# Altium schematic sheet power_supply.SchDoc
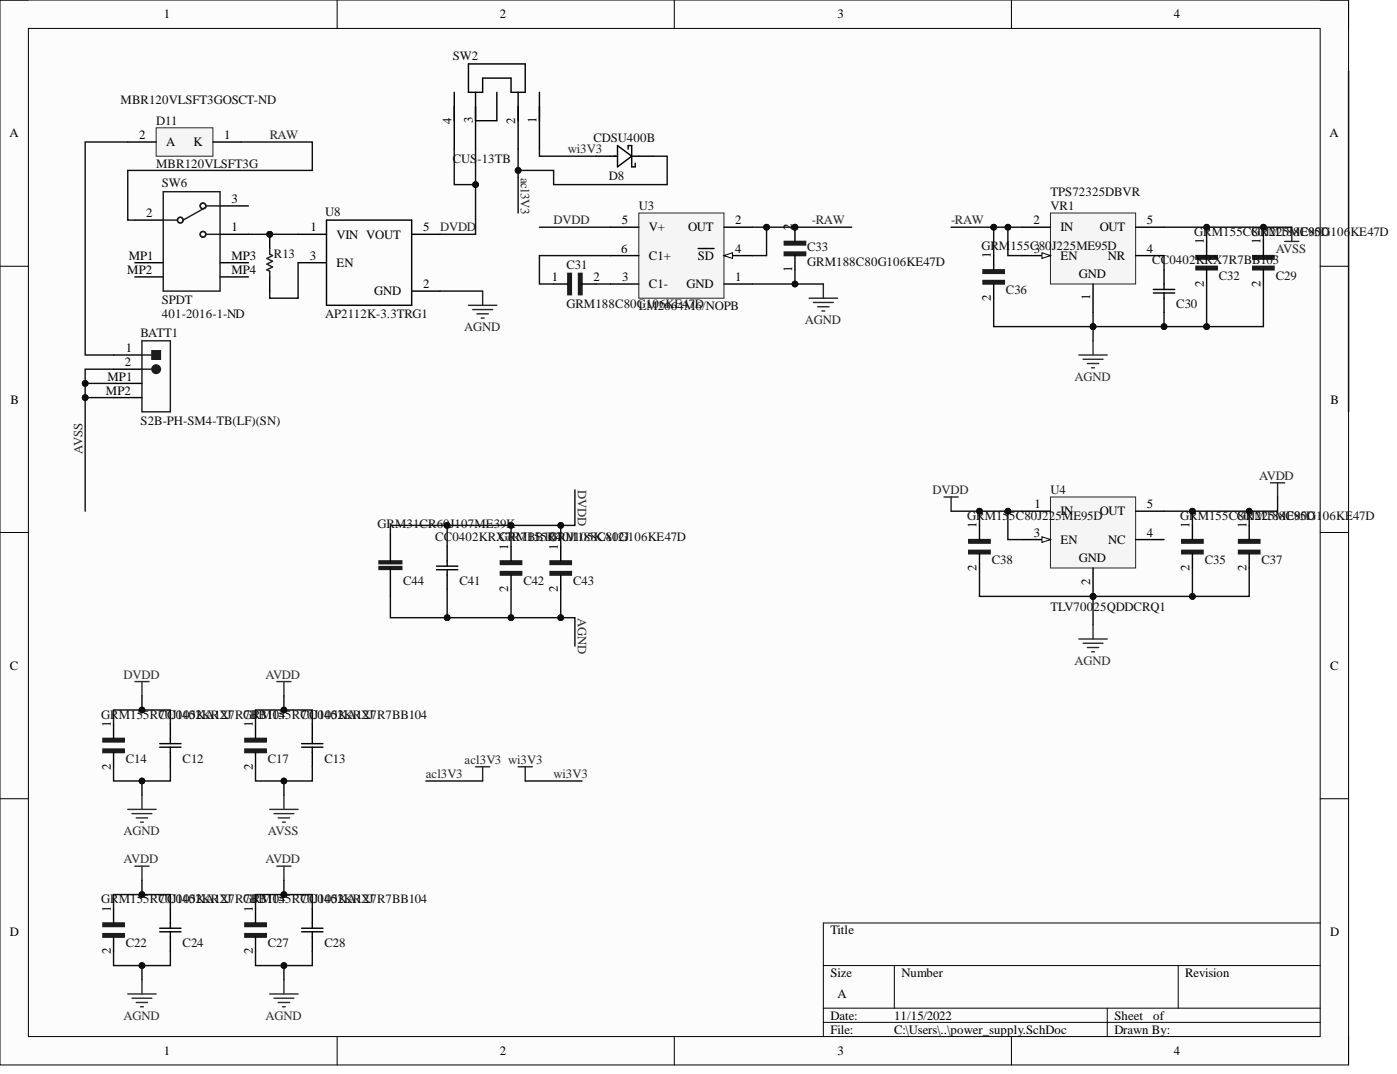
[diagram p0: power_supply.SchDoc]

## Parts
BATT1  S2B-PH-SM4-TB(LF)(SN)  [JST- S2B-PH-SM4-TB(LF)(SN)-2_V]
C12  CC0402KRX7R7BB104  [YAG_CC0402]
C13  CC0402KRX7R7BB104  [YAG_CC0402]
C14  GRM155R70J105KA12J  [*CAPC1005X55N]
C17  GRM155R70J105KA12J  [*CAPC1005X55N]
C22  GRM155R70J105KA12J  [*CAPC1005X55N]
C24  CC0402KRX7R7BB104  [YAG_CC0402]
C27  GRM155R70J105KA12J  [*CAPC1005X55N]
C28  CC0402KRX7R7BB104  [YAG_CC0402]
C29  GRM188C80G106KE47D  [*CAPC1608X90N]
C30  CC0402KRX7R7BB103  [CC0402N]
C31  GRM188C80G106KE47D  [*CAPC1608X90N]
C32  GRM155C80J225ME95D  [*CAPC1005X55N]
C33  GRM188C80G106KE47D  [*CAPC1608X90N]
C35  GRM155C80J225ME95D  [*CAPC1005X55N]
C36  GRM155C80J225ME95D  [*CAPC1005X55N]
C37  GRM188C80G106KE47D  [*CAPC1608X90N]
C38  GRM155C80J225ME95D  [*CAPC1005X55N]
C41  CC0402KRX7R7BB104  [YAG_CC0402]
C42  GRM155R70J105KA12J  [*CAPC1005X55N]
C43  GRM188C80G106KE47D  [*CAPC1608X90N]
C44  GRM31CR60J107ME39K  [*CAPC3216X190]
D8  CDSU400B  [CDBU]
D11  MBR120VLSFT3G  Supplier Part Number 1: MBR120VLSFT3GOSCT-ND  [ONSC-SOD-123FL-2-498-01_V]
R13  10K  [RESC1609X55X30NL15T15]  (value not drawn)
SW2  CUS-13TB  [CUS-13TB]
SW6  SPDT  [CKCP-PCM12SMTR_V]
U3  LM2664M6/NOPB  [DBV0006A_N]
U4  TLV70025QDDCRQ1  [DDC0005A_N]
U8  AP2112K-3.3TRG1  [*SOT95P285X140-5N]
VR1  TPS72325DBVR  [DBV0005A_N]

## Nets
AVDD: C13.1 C17.1 C22.1 C24.1 C27.1 C28.1 C35.1 C37.1 U4.5(OUT)
-RAW: C33.2 C36.1 U3.2(OUT) U3.4(SD) VR1.2(IN) VR1.3(EN)
acl3V3: D8.1 SW2.2
AGND: C12.2 C14.2 C22.2 C24.2 C27.2 C28.2 C29.2 C30.1 C32.2 C33.1 C35.2 C36.2 C37.2 C38.2 C41.2 C42.2 C43.2 C44.2 U3.1(GND) U4.2 U8.2(GND) VR1.1
AVSS: BATT1.2 BATT1.MP1 BATT1.MP2 C13.2 C17.2 C29.1 C32.1 VR1.5(OUT)
DVDD: C12.1 C14.1 C38.1 C41.1 C42.1 C43.1 C44.1 SW2.3 SW2.4 U3.5(V+) U4.1(IN) U4.3(EN) U8.5(VOUT)
RAW: D11.1 SW6.2
wi3V3: D8.2 SW2.1
NetBATT1_1: BATT1.1 D11.2
NetC30_2: C30.2 VR1.4(NR)
NetC31_1: C31.1 U3.6(C1+)
NetC31_2: C31.2 U3.3(C1-)
NetR13_1: R13.1 U8.3(EN)
NetR13_2: R13.2 SW6.1 U8.1(VIN)

Not connected: SW6 3 MP1 MP2 MP3 MP4; U4 4(NC)

## Library data of the parts
BATT1 = S2B-PH-SM4-TB(LF)(SN): Manufacturer 1: JST; Component Kind: Standard; Manufacturer Part Number 1: S2B-PH-SM4-TB(LF)(SN); Pricing 1: 1=0.58000, 10=0.54700, 100=0.41940, 500=0.36470 (USD); RoHS: No; Stock 1: 99373; Supplier 1: Digi-Key; Supplier Part Number 1: 455-1749-1-ND; Library Name: EE_smallOB_BW_v1.0.SchLib
C12 C13 C24 C28 C41 = CC0402KRX7R7BB104: CAP CER 0.1UF 16V X7R 0402; Manufacturer 1: Yageo; Capacitance: 100nF; Tolerance: 10%; Case Code (Imperial): 0402; Case Code (Metric): 1005; Case/Package: 0402; Component Kind: Standard; ComponentLink1Description: https://datasheet.ciiva.com/11788/0900766b80ce67ed-11788364.pdf?src-supplier=RSComponents; ComponentLink1URL: https://datasheet.ciiva.com/11788/0900766b80ce67ed-11788364.pdf?src-supplier=RSComponents; ComponentLink2Description: https://datasheet.ciiva.com/16625/upy-gphc-x7r-6-3v-to-50v-8-16625511.pdf?src-supplier=Avnet+Europe; ComponentLink2URL: https://datasheet.ciiva.com/16625/upy-gphc-x7r-6-3v-to-50v-8-16625511.pdf?src-supplier=Avnet+Europe; ComponentLink3Description: https://datasheet.ciiva.com/161/mlccorderingcode-161544.pdf?src-supplier=Digi-Key; ComponentLink3URL: https://datasheet.ciiva.com/161/mlccorderingcode-161544.pdf?src-supplier=Digi-Key; ComponentLink4Description: https://datasheet.ciiva.com/161/mlccorderingcode-161545.pdf?src-supplier=Digi-Key; ComponentLink4URL: https://datasheet.ciiva.com/161/mlccorderingcode-161545.pdf?src-supplier=Digi-Key; ComponentLink5Description: https://datasheet.ciiva.com/8033/1734573-8033661.pdf?src-supplier=Element14; ComponentLink5URL: https://datasheet.ciiva.com/8033/1734573-8033661.pdf?src-supplier=Element14; ComponentLink6Description: https://datasheet.ciiva.com/7978/1489800-7978111.pdf?src-supplier=Element14; ComponentLink6URL: https://datasheet.ciiva.com/7978/1489800-7978111.pdf?src-supplier=Element14; ComponentLink7Description: https://datasheet.ciiva.com/8033/1734573-8033663.pdf?src-supplier=Element14; ComponentLink7URL: https://datasheet.ciiva.com/8033/1734573-8033663.pdf?src-supplier=Element14; ComponentLink8Description: https://datasheet.ciiva.com/11587/upy-gphc-x7r-6-3v-to-50v-8-11587305.pdf?src-supplier=Avnet; ComponentLink8URL: https://datasheet.ciiva.com/11587/upy-gphc-x7r-6-3v-to-50v-8-11587305.pdf?src-supplier=Avnet; ComponentLink9Description: https://datasheet.ciiva.com/161/mlccorderingcode-161546.pdf?src-supplier=Digi-Key; ComponentLink9URL: https://datasheet.ciiva.com/161/mlccorderingcode-161546.pdf?src-supplier=Digi-Key; ComponentLink10Description: https://datasheet.ciiva.com/13395/upy-gphc-x7r-6-3v-to-50v-8-13395676.pdf?src-supplier=Mouser; ComponentLink10URL: https://datasheet.ciiva.com/13395/upy-gphc-x7r-6-3v-to-50v-8-13395676.pdf?src-supplier=Mouser; ComponentLink11Description: https://datasheet.ciiva.com/28450/1489800-28450335.pdf?src-supplier=Element14; ComponentLink11URL: https://datasheet.ciiva.com/28450/1489800-28450335.pdf?src-supplier=Element14; Composition: Ceramic; Depth: 0.5mm; Dielectric: X7R; Halogen Free: Halogen Free; Height: 0.5mm; Lead Free: Lead Free; Length: 1mm; Manufacturer Part Number 1: CC0402KRX7R7BB104; Max Operating Temperature: 125°C; Min Operating Temperature: -55°C; Mount: Surface Mount; Number of Pins: 2; Package Quantity: 1; Packaging: Tape and Reel; Pricing 1: 1=0.10000, 10=0.03200, 100=0.01420, 500=0.01014, 1000=0.00797, 2500=0.00724, 5000=0.00667 (USD); Radiation Hardening: No; REACH SVHC: No SVHC; RoHS: Yes; Stock 1: 30032352; Supplier 1: Digi-Key; Supplier Part Number 1: 311-1338-1-ND; Termination: SMD/SMT; Thickness: 0.022inch; Voltage: 16V; Voltage Rating: 16V; Voltage Rating (DC): 16V; Width: 0.02inch; Library Name: EE_smallOB_BW_v1.0.SchLib
C14 C17 C22 C27 C42 = GRM155R70J105KA12J: CAP CER 1UF 6.3V X7R 0402; Manufacturer 1: Murata; Tolerance: 10%; Capacitance: 1uF; Case Code (Imperial): 0402; Case Code (Metric): 1005; Case/Package: 0402; Component Kind: Standard; ComponentLink1Description: http://www.mouser.com/ds/2/281/c02e-2905.pdf?src-supplier=Mouser; ComponentLink1URL: http://www.mouser.com/ds/2/281/c02e-2905.pdf?src-supplier=Mouser; ComponentLink2Description: http://search.murata.co.jp/Ceramy/image/img/A01X/G101/ENG/GRM155R70J105KA12-01.pdf?src-supplier=Digi-Key; ComponentLink2URL: http://search.murata.co.jp/Ceramy/image/img/A01X/G101/ENG/GRM155R70J105KA12-01.pdf?src-supplier=Digi-Key; Dielectric: X7R; Length: 0.039inch; Manufacturer Part Number 1: GRM155R70J105KA12J; Max Operating Temperature: 125°C; Min Operating Temperature: -55°C; Mount: Surface Mount; Package Quantity: 50000; Packaging: Tape and Reel; Pricing 1: 1=0.11000, 10=0.07900, 100=0.03700, 500=0.02596, 1000=0.02149, 2500=0.02000, 5000=0.01830 (USD); RoHS: Yes; Stock 1: 3268614; Supplier 1: Digi-Key; Supplier Part Number 1: 490-13339-1-ND; Termination: SMD/SMT; Thickness: 0.022inch; Voltage Rating: 6.3V; Voltage Rating (DC): 6.3V; Weight: 0.000212oz; Width: 0.02inch; Library Name: EE_smallOB_BW_v1.0.SchLib
C29 C31 C33 C37 C43 = GRM188C80G106KE47D: CAP CER 10UF 4V X6S 0603; Manufacturer 1: Murata; Tolerance: 10%; Capacitance: 10uF; Case Code (Imperial): 0603; Case Code (Metric): 1608; Case/Package: 0603; Component Kind: Standard; ComponentLink1Description: http://www.mouser.com/ds/2/281/c02e-2905.pdf?src-supplier=Mouser; ComponentLink1URL: http://www.mouser.com/ds/2/281/c02e-2905.pdf?src-supplier=Mouser; ComponentLink2Description: http://search.murata.co.jp/Ceramy/image/img/A01X/G101/ENG/GRM188C80G106KE47-01.pdf?src-supplier=Digi-Key; ComponentLink2URL: http://search.murata.co.jp/Ceramy/image/img/A01X/G101/ENG/GRM188C80G106KE47-01.pdf?src-supplier=Digi-Key; Dielectric: X6S; Height: 0.8mm; Length: 0.063inch; Manufacturer Part Number 1: GRM188C80G106KE47D; Max Operating Temperature: 105°C; Min Operating Temperature: -55°C; Mount: Surface Mount; Package Quantity: 4000; Packaging: Tape and Reel; Pricing 1: 1=0.17000, 10=0.11800, 100=0.05990, 500=0.04098, 1000=0.03625 (USD); RoHS: Yes; Stock 1: 203819; Supplier 1: Digi-Key; Supplier Part Number 1: 490-10469-1-ND; Termination: SMD/SMT; Thickness: 0.035inch; Voltage Rating: 4V; Voltage Rating (DC): 4V; Weight: 0.000222oz; Width: 0.031inch; Library Name: EE_smallOB_BW_v1.0.SchLib
C30 = CC0402KRX7R7BB103: CAP CER 10000PF 16V X7R 0402; Manufacturer 1: Yageo; Capacitance: 10nF; Dissipation Factor: 3.5%; Insulation Resistance: 10GR; Tolerance: 10%; Case Code (Imperial): 0402; Case Code (Metric): 1005; Case/Package: 0402; Component Kind: Standard; ComponentLink1Description: https://datasheet.ciiva.com/143/upy-gphc-x7r-6-3v-to-50v-8-143952.pdf?src-supplier=Digi-Key; ComponentLink1URL: https://datasheet.ciiva.com/143/upy-gphc-x7r-6-3v-to-50v-8-143952.pdf?src-supplier=Digi-Key; ComponentLink2Description: https://datasheet.ciiva.com/143/mlccorderingcode-143950.pdf?src-supplier=Digi-Key; ComponentLink2URL: https://datasheet.ciiva.com/143/mlccorderingcode-143950.pdf?src-supplier=Digi-Key; ComponentLink3Description: https://datasheet.ciiva.com/143/mlccorderingcode-143951.pdf?src-supplier=Digi-Key; ComponentLink3URL: https://datasheet.ciiva.com/143/mlccorderingcode-143951.pdf?src-supplier=Digi-Key; ComponentLink4Description: https://datasheet.ciiva.com/16625/upy-gphc-x7r-6-3v-to-50v-8-16625510.pdf?src-supplier=Avnet+Europe; ComponentLink4URL: https://datasheet.ciiva.com/16625/upy-gphc-x7r-6-3v-to-50v-8-16625510.pdf?src-supplier=Avnet+Europe; ComponentLink5Description: https://datasheet.ciiva.com/8033/70765-8033655.pdf?src-supplier=Element14; ComponentLink5URL: https://datasheet.ciiva.com/8033/70765-8033655.pdf?src-supplier=Element14; ComponentLink6Description: https://datasheet.ciiva.com/8033/1489800-8033658.pdf?src-supplier=Element14; ComponentLink6URL: https://datasheet.ciiva.com/8033/1489800-8033658.pdf?src-supplier=Element14; ComponentLink7Description: https://datasheet.ciiva.com/28450/1489800-28450330.pdf?src-supplier=Element14; ComponentLink7URL: https://datasheet.ciiva.com/28450/1489800-28450330.pdf?src-supplier=Element14; ComponentLink8Description: https://datasheet.ciiva.com/8033/1489800-8033659.pdf?src-supplier=Element14; ComponentLink8URL: https://datasheet.ciiva.com/8033/1489800-8033659.pdf?src-supplier=Element14; ComponentLink9Description: https://datasheet.ciiva.com/7978/1489800-7978109.pdf?src-supplier=Element14; ComponentLink9URL: https://datasheet.ciiva.com/7978/1489800-7978109.pdf?src-supplier=Element14; ComponentLink10Description: https://datasheet.ciiva.com/5425/upy-gp-np0-16v-to-50v-8-3714-5425438.pdf?src-supplier=Mouser; ComponentLink10URL: https://datasheet.ciiva.com/5425/upy-gp-np0-16v-to-50v-8-3714-5425438.pdf?src-supplier=Mouser; Depth: 0.5mm; Dielectric: X7R; Halogen Free: Halogen Free; Height: 0.5mm; Lead Free: Lead Free; Length: 1mm; Manufacturer Part Number 1: CC0402KRX7R7BB103; Max Operating Temperature: 125°C; Min Operating Temperature: -55°C; Mount: Surface Mount; Number of Pins: 2; Package Quantity: 1; Packaging: Tape and Reel; Pricing 1: 1=0.10000, 10=0.01900, 100=0.00850, 500=0.00610, 1000=0.00479, 2500=0.00436, 5000=0.00401 (USD); Radiation Hardening: No; REACH SVHC: No SVHC; RoHS: Yes; Stock 1: 1055821; Supplier 1: Digi-Key; Supplier Part Number 1: 311-1042-1-ND; Termination: SMD/SMT; Thickness: 0.022inch; Voltage: 16V; Voltage Rating: 16V; Voltage Rating (DC): 16V; Width: 0.02inch; Library Name: EE_smallOB_BW_v1.0.SchLib
C32 C35 C36 C38 = GRM155C80J225ME95D: 0402 6.3 V 2.2 uF ±20% Tolerance X6S Monolithic Ceramic Chip Capacitor; Manufacturer 1: Murata; Capacitance: 2.2uF; Voltage Rating: 6.3V; Case Code (Imperial): 0402; Case Code (Metric): 1005; Case/Package: 0402; Component Kind: Standard; ComponentLink1Description: http://www.mouser.com/ds/2/281/c02e-2905.pdf?src-supplier=Mouser; ComponentLink1URL: http://www.mouser.com/ds/2/281/c02e-2905.pdf?src-supplier=Mouser; ComponentLink2Description: http://search.murata.co.jp/Ceramy/image/img/A01X/partnumbering_e_01.pdf?src-supplier=Digi-Key; ComponentLink2URL: http://search.murata.co.jp/Ceramy/image/img/A01X/partnumbering_e_01.pdf?src-supplier=Digi-Key; Dielectric: X6S; Height: 0.5mm; Length: 0.039inch; Manufacturer Part Number 1: GRM155C80J225ME95D; Max Operating Temperature: 105°C; Min Operating Temperature: -55°C; Mount: Surface Mount; Package Quantity: 10000; Packaging: Tape and Reel; Pricing 1: 1=0.12000, 10=0.08200, 100=0.03850, 500=0.02702, 1000=0.02237, 2500=0.02082, 5000=0.01905 (USD); RoHS: Yes; Stock 1: 46738; Supplier 1: Digi-Key; Supplier Part Number 1: 490-10013-1-ND; Termination: SMD/SMT; Thickness: 0.022inch; Tolerance: 20%; Voltage Rating (DC): 6.3V; Weight: 0.000212oz; Width: 0.5mm; Library Name: EE_smallOB_BW_v1.0.SchLib
C44 = GRM31CR60J107ME39K: MURATA - GRM31CR60J107ME39K - COND, 100µF, 6.3V, 20%, X5R, 1206; Capacitance: 100uF; Case Code (Imperial): 1206; Case Code (Metric): 3216; Case/Package: 1206; Component Kind: Standard; ComponentLink1Description: https://datasheet.ciiva.com/13246/product-13246228.pdf?src-supplier=Mouser; ComponentLink1URL: https://datasheet.ciiva.com/13246/product-13246228.pdf?src-supplier=Mouser; ComponentLink2Description: https://datasheet.ciiva.com/18697/grm31cr60j107me39-01-18697367.pdf?src-supplier=Digi-Key; ComponentLink2URL: https://datasheet.ciiva.com/18697/grm31cr60j107me39-01-18697367.pdf?src-supplier=Digi-Key; ComponentLink3Description: https://datasheet.ciiva.com/18697/grm31cr60j107me39-01-18697368.pdf?src-supplier=Digi-Key; ComponentLink3URL: https://datasheet.ciiva.com/18697/grm31cr60j107me39-01-18697368.pdf?src-supplier=Digi-Key; ComponentLink4Description: https://datasheet.ciiva.com/18697/grm31cr60j107me39-01-18697369.pdf?src-supplier=Digi-Key; ComponentLink4URL: https://datasheet.ciiva.com/18697/grm31cr60j107me39-01-18697369.pdf?src-supplier=Digi-Key; Depth: 1.6mm; Dielectric: X5R; Height: 1.6mm; Lead Free: Lead Free; Length: 3.2mm; Manufacturer 1: Murata; Manufacturer Part Number 1: GRM31CR60J107ME39K; Max Operating Temperature: 85°C; Min Operating Temperature: -55°C; Mount: Surface Mount; Package Quantity: 1; Packaging: Tape and Reel; Pricing 1: 1=0.98000, 10=0.77400, 100=0.58060, 500=0.43870, 1000=0.38709, 2500=0.36128 (USD); Radiation Hardening: No; REACH SVHC: No SVHC; RoHS: Yes; Stock 1: 407390; Supplier 1: Digi-Key; Supplier Part Number 1: 490-7217-1-ND; Termination: SMD/SMT; Thickness: 0.071inch; Tolerance: 20%; Voltage: 6.3V; Voltage Rating: 6.3V; Voltage Rating (DC): 6.3V; Width: 0.063inch; Library Name: EE_smallOB_BW_v1.0.SchLib
D8 = CDSU400B: DIODE GEN PURP 80V 100MA 0603; Manufacturer 1: Comchip; Max Power Dissipation: 150mW; Max Reverse Leakage Current: 100nA; Max Surge Current: 1A; Recovery Time: 4ns; Average Rectified Current: 100mA; Case/Package: SMD/SMT; Component Kind: Standard; ComponentLink1Description: https://datasheet.ciiva.com/911/cdsu400b-revb370614-911825.pdf?src-supplier=Digi-Key; ComponentLink1URL: https://datasheet.ciiva.com/911/cdsu400b-revb370614-911825.pdf?src-supplier=Digi-Key; ComponentLink2Description: https://datasheet.ciiva.com/4435/cdsu400b-revb370614-56727-4435278.pdf?src-supplier=Mouser; ComponentLink2URL: https://datasheet.ciiva.com/4435/cdsu400b-revb370614-56727-4435278.pdf?src-supplier=Mouser; ComponentLink3Description: https://datasheet.ciiva.com/911/cdsu400b-revb370614-911826.pdf?src-supplier=Digi-Key; ComponentLink3URL: https://datasheet.ciiva.com/911/cdsu400b-revb370614-911826.pdf?src-supplier=Digi-Key; ComponentLink4Description: https://datasheet.ciiva.com/911/cdsu400b-revb370614-911827.pdf?src-supplier=Digi-Key; ComponentLink4URL: https://datasheet.ciiva.com/911/cdsu400b-revb370614-911827.pdf?src-supplier=Digi-Key; Manufacturer Part Number 1: CDSU400B; Max Reverse Voltage (DC): 80V; Mount: Surface Mount; Package Quantity: 1; Packaging: Tape and Reel; Pricing 1: 1=0.22000, 10=0.17700, 100=0.09390, 500=0.06180, 1000=0.04202 (USD); Reverse Recovery Time: 4ns; RoHS: Yes; Stock 1: 61022; Supplier 1: Digi-Key; Supplier Part Number 1: 641-1003-1-ND; Library Name: EE_smallOB_BW_v1.0.SchLib
D11 = MBR120VLSFT3G: MBR120VLSF Series 20 V 1 A Surface Mount Schottky Power Rectifier - SOD-123FL; Manufacturer 1: ON Semiconductor; Average Rectified Current: 1A; Element Configuration: Single; Forward Voltage: 340mV; Max Forward Surge Current (Ifsm): 45A; Max Repetitive Reverse Voltage (Vrrm): 20V; Max Reverse Leakage Current: 600uA; Polarity: Standard; Component Kind: Standard; ComponentLink1Description: https://datasheet.ciiva.com/4715/mbr120vlsft1-d-107081-4715104.pdf?src-supplier=Mouser; ComponentLink1URL: https://datasheet.ciiva.com/4715/mbr120vlsft1-d-107081-4715104.pdf?src-supplier=Mouser; ComponentLink2Description: https://datasheet.ciiva.com/6380/mbr120vlsft1-d-6380907.pdf?src-supplier=Digi-Key; ComponentLink2URL: https://datasheet.ciiva.com/6380/mbr120vlsft1-d-6380907.pdf?src-supplier=Digi-Key; ComponentLink3Description: https://datasheet.ciiva.com/8294/mbr120vlsft1-d-8294603.pdf?src-supplier=Avnet; ComponentLink3URL: https://datasheet.ciiva.com/8294/mbr120vlsft1-d-8294603.pdf?src-supplier=Avnet; ComponentLink4Description: https://datasheet.ciiva.com/6380/mbr120vlsft1-d-6380910.pdf?src-supplier=Digi-Key; ComponentLink4URL: https://datasheet.ciiva.com/6380/mbr120vlsft1-d-6380910.pdf?src-supplier=Digi-Key; Current Rating: 1A; Forward Current: 1A; Halogen Free: Halogen Free; Lead Free: Lead Free; Manufacturer Part Number 1: MBR120VLSFT3G; Max Operating Temperature: 125°C; Max Reverse Voltage (DC): 20V; Max Surge Current: 45A; Min Operating Temperature: -65°C; Number of Pins: 2; Output Current: 1A; Package Quantity: 10000; Packaging: Tape and Reel; Peak Non-Repetitive Surge Current: 45A; Peak Reverse Current: 600uA; Pricing 1: 1=0.40000, 10=0.32100, 100=0.21880, 500=0.16414, 1000=0.12310 (USD); Radiation Hardening: No; REACH SVHC: No SVHC; RoHS: Yes; Stock 1: 101961; Supplier 1: Digi-Key; Voltage Rating (DC): 20V; Library Name: EE_smallOB_BW_v1.0.SchLib
R13 = ERJ-3EKF1002V: Res Thick Film 0603 10K Ohm 1% 1/10W ±100ppm/°C Molded SMD Punched Carrier T/R; Manufacturer 1: Panasonic; Max Power Dissipation: 100mW; Resistance: 10kR; Voltage Rating: 75V; Case Code (Imperial): 0603; Case Code (Metric): 1608; Case/Package: 0603; Component Kind: Standard; ComponentLink1Description: http://www.farnell.com/datasheets/1911175.pdf?src-supplier=Farnell; ComponentLink1URL: http://www.farnell.com/datasheets/1911175.pdf?src-supplier=Farnell; ComponentLink2Description: http://components.arrow.com/part/dataview/0323_02069964?src-supplier=Arrow+Europe; ComponentLink2URL: http://components.arrow.com/part/dataview/0323_02069964?src-supplier=Arrow+Europe; ComponentLink3Description: https://industrial.panasonic.com/cdbs/www-data/pdf/RDA0000/DMA0000COL11.pdf?src-supplier=Distrelec; ComponentLink3URL: https://industrial.panasonic.com/cdbs/www-data/pdf/RDA0000/DMA0000COL11.pdf?src-supplier=Distrelec; ComponentLink4Description: https://industrial.panasonic.com/cdbs/www-data/pdf/RDA0000/DMA0000COL11.pdf?src-supplier=Future+Electronics; ComponentLink4URL: https://industrial.panasonic.com/cdbs/www-data/pdf/RDA0000/DMA0000COL11.pdf?src-supplier=Future+Electronics; ComponentLink5Description: http://www.jameco.com/Jameco/Products/ProdDS/1987468.pdf?src-supplier=Jameco; ComponentLink5URL: http://www.jameco.com/Jameco/Products/ProdDS/1987468.pdf?src-supplier=Jameco; ComponentLink6Description: https://industrial.panasonic.com/cdbs/www-data/pdf/RDA0000/DMA0000COL11.pdf?src-supplier=Allied+Electronics; ComponentLink6URL: https://industrial.panasonic.com/cdbs/www-data/pdf/RDA0000/DMA0000COL11.pdf?src-supplier=Allied+Electronics; ComponentLink7Description: https://industrial.panasonic.com/cdbs/www-data/pdf/RDA0000/DMA0000COL11.pdf?src-supplier=Conrad; ComponentLink7URL: https://industrial.panasonic.com/cdbs/www-data/pdf/RDA0000/DMA0000COL11.pdf?src-supplier=Conrad; Composition: Thick Film; Contact Plating: Tin; Depth: 0.8mm; Features: Automotive AEC-Q200; Height: 0.45mm; Lead Free: Lead Free; Length: 1.6mm; Manufacturer Part Number 1: ERJ-3EKF1002V; Max Operating Temperature: 155°C; Military Standard: Not; Min Operating Temperature: -55°C; Mount: Surface Mount; Number of Pins: 2; Number of Terminations: 2; Package Quantity: 1; Packaging: Tape and Reel; Power Rating: 100mW; Pricing 1: 1=0.10000, 10=0.06500, 100=0.02640, 1000=0.01186, 2500=0.01029 (USD); Radiation Hardening: No; REACH SVHC: No SVHC; RoHS: Yes; Stock 1: 6176372; Supplier 1: Digi-Key; Temperature Coefficient: 100ppm/°C; Termination: SMD/SMT; Tolerance: 1%; Voltage Rating (DC): 75V; Width: 0.031inch; Library Name: EE_smallOB_BW_v1.0.SchLib
SW2 = CUS-13TB: SWITCH SLIDE SP3T 300MA 4V; Manufacturer 1: Nidec Copal; Actuator Length: 1.5mm; Actuator Material: Nylon,Polyamide; Actuator Type: Standard; Electrical Life: 10000Cycles; Max Voltage Rating (DC): 20V; Switch Function: On-On-On; Component Kind: Standard; ComponentLink1Description: https://datasheet.ciiva.com/2889/cus-2889142.pdf?src-supplier=Digi-Key; ComponentLink1URL: https://datasheet.ciiva.com/2889/cus-2889142.pdf?src-supplier=Digi-Key; ComponentLink2Description: https://datasheet.ciiva.com/2889/cus-2889143.pdf?src-supplier=Digi-Key; ComponentLink2URL: https://datasheet.ciiva.com/2889/cus-2889143.pdf?src-supplier=Digi-Key; ComponentLink3Description: https://datasheet.ciiva.com/2889/cus-2889144.pdf?src-supplier=Digi-Key; ComponentLink3URL: https://datasheet.ciiva.com/2889/cus-2889144.pdf?src-supplier=Digi-Key; Contact Material: Copper Alloy; Contact Plating: Gold; Current Rating: 300mA; Manufacturer Part Number 1: CUS-13TB; Max Operating Temperature: 70°C; Min Operating Temperature: -20°C; Mount: Surface Mount; Orientation: Right Angle; Packaging: Tape and Reel; Pricing 1: 1=0.74000, 10=0.71200, 25=0.69760, 50=0.62320, 100=0.59360, 250=0.57876, 500=0.48972, 1000=0.47488 (USD); Radiation Hardening: No; RoHS: Yes; Stock 1: 8665; Supplier 1: Digi-Key; Supplier Part Number 1: 563-1103-1-ND; Switch Travel: 1.5mm; Termination: Gull Wing; Throw Configuration: SP3T; Voltage Rating (DC): 4V; Library Name: EE_smallOB_BW_v1.0.SchLib
SW6 = PCM12SMTR: C & K COMPONENTS - PCM12SMTR - SWITCH, SPDT, 0.3A, 6VDC, SMD; Manufacturer 1: ITT C&K; Actuator Length: 1.5mm; Actuator Material: Nylon,Polyamide; Actuator Type: Standard; Contact Current Rating: 300mA; Contact Resistance: 70mR; Insulation Resistance: 100mR; Max Voltage Rating (DC): 6V; Mechanical Life: 10000Cycles; Switch Function: On-On; Component Kind: Standard; ComponentLink1Description: https://datasheet.ciiva.com/16462/pcm-13nov13-16462516.pdf?src-supplier=Avnet+Asia; ComponentLink1URL: https://datasheet.ciiva.com/16462/pcm-13nov13-16462516.pdf?src-supplier=Avnet+Asia; ComponentLink2Description: https://datasheet.ciiva.com/16475/pcm12smtr-16475766.pdf?src-supplier=Future+Electronics; ComponentLink2URL: https://datasheet.ciiva.com/16475/pcm12smtr-16475766.pdf?src-supplier=Future+Electronics; ComponentLink3Description: https://datasheet.ciiva.com/16174/pcm-30mar11-16174552.pdf?src-supplier=Onlinecomponents.com; ComponentLink3URL: https://datasheet.ciiva.com/16174/pcm-30mar11-16174552.pdf?src-supplier=Onlinecomponents.com; ComponentLink4Description: https://datasheet.ciiva.com/1532/1685524-1532411.pdf?src-supplier=Element14; ComponentLink4URL: https://datasheet.ciiva.com/1532/1685524-1532411.pdf?src-supplier=Element14; ComponentLink5Description: https://datasheet.ciiva.com/5279/pcm-5oct11-24112-5279535.pdf?src-supplier=Mouser; ComponentLink5URL: https://datasheet.ciiva.com/5279/pcm-5oct11-24112-5279535.pdf?src-supplier=Mouser; ComponentLink6Description: https://datasheet.ciiva.com/2889/pcm-13nov13-2889154.pdf?src-supplier=Digi-Key; ComponentLink6URL: https://datasheet.ciiva.com/2889/pcm-13nov13-2889154.pdf?src-supplier=Digi-Key; ComponentLink7Description: https://datasheet.ciiva.com/2889/pcm-13nov13-2889155.pdf?src-supplier=Digi-Key; ComponentLink7URL: https://datasheet.ciiva.com/2889/pcm-13nov13-2889155.pdf?src-supplier=Digi-Key; ComponentLink8Description: https://datasheet.ciiva.com/2889/pcm-13nov13-2889156.pdf?src-supplier=Digi-Key; ComponentLink8URL: https://datasheet.ciiva.com/2889/pcm-13nov13-2889156.pdf?src-supplier=Digi-Key; Contact Material: Copper Alloy,Copper,Silver; Contact Plating: Silver; Current Rating: 300mA; Depth: 5mm; Lead Free: Lead Free; Length: 7.7mm; Manufacturer Part Number 1: PCM12SMTR; Max Current Rating: 300mA; Max Operating Temperature: 85°C; Min Operating Temperature: -20°C; Mount: Surface Mount; Orientation: Right Angle; Package Quantity: 1; Packaging: Tape and Reel; Pricing 1: 1=0.91000, 10=0.87000, 25=0.85240, 50=0.76160, 100=0.72530, 250=0.70720, 500=0.59840, 1000=0.58027 (USD); REACH SVHC: No SVHC; RoHS: Yes; Stock 1: 24379; Supplier 1: Digi-Key; Supplier Part Number 1: 401-2016-1-ND; Switch Travel: 1.5mm; Termination: Gull Wing; Throw Configuration: SPDT; Voltage Rating (AC): 6V; Voltage Rating (DC): 6V; Width: 6.7mm; Library Name: EE_smallOB_BW_v1.0.SchLib
U3 = LM2664M6/NOPB: IC REG SWTCHD CAP INV 40MA SOT23; Manufacturer 1: TI National Semiconductor; Frequency: 160kHz; Logic Function: Inverting; Max Input Voltage: 5.5V; Max Output Voltage: -1.8V; Max Power Dissipation: 600mW; Min Input Voltage: 1.8V; Min Output Voltage: -5.5V; Nominal Supply Current: 500uA; Number of Outputs: 1; Output Power: 500mW; Output Type: Adjustable; Topology: Buck-Boost; Case/Package: SOT-23; Component Kind: Standard; ComponentLink1Description: https://datasheet.ciiva.com/1641/1703149-1641090.pdf?src-supplier=Newark; ComponentLink1URL: https://datasheet.ciiva.com/1641/1703149-1641090.pdf?src-supplier=Newark; ComponentLink2Description: https://datasheet.ciiva.com/15114/getdatasheetpartid-117448-15114290.pdf?src-supplier=Verical; ComponentLink2URL: https://datasheet.ciiva.com/15114/getdatasheetpartid-117448-15114290.pdf?src-supplier=Verical; ComponentLink3Description: https://datasheet.ciiva.com/27055/1992911-27055843.pdf?src-supplier=Jameco; ComponentLink3URL: https://datasheet.ciiva.com/27055/1992911-27055843.pdf?src-supplier=Jameco; ComponentLink4Description: https://datasheet.ciiva.com/22669/1811104-22669560.pdf?src-supplier=Element14; ComponentLink4URL: https://datasheet.ciiva.com/22669/1811104-22669560.pdf?src-supplier=Element14; ComponentLink5Description: https://datasheet.ciiva.com/5699/1853535-5699178.pdf?src-supplier=Farnell; ComponentLink5URL: https://datasheet.ciiva.com/5699/1853535-5699178.pdf?src-supplier=Farnell; ComponentLink6Description: https://datasheet.ciiva.com/6579/lm2664-6579834.pdf?src-supplier=Digi-Key; ComponentLink6URL: https://datasheet.ciiva.com/6579/lm2664-6579834.pdf?src-supplier=Digi-Key; ComponentLink7Description: https://datasheet.ciiva.com/6579/lm2664-6579836.pdf?src-supplier=Digi-Key; ComponentLink7URL: https://datasheet.ciiva.com/6579/lm2664-6579836.pdf?src-supplier=Digi-Key; ComponentLink8Description: https://datasheet.ciiva.com/6579/lm2664-6579837.pdf?src-supplier=Digi-Key; ComponentLink8URL: https://datasheet.ciiva.com/6579/lm2664-6579837.pdf?src-supplier=Digi-Key; ComponentLink9Description: https://datasheet.ciiva.com/25902/lm2664-25902046.pdf?src-supplier=Avnet+Europe; ComponentLink9URL: https://datasheet.ciiva.com/25902/lm2664-25902046.pdf?src-supplier=Avnet+Europe; Height: 1.02mm; Lead Free: Lead Free; Length: 2.92mm; Manufacturer Part Number 1: LM2664M6/NOPB; Max Operating Temperature: 85°C; Max Output Current: 40mA; Max Supply Voltage: 5.5V; Min Operating Temperature: -40°C; Min Supply Voltage: 1.8V; Mount: Surface Mount; Number of Pins: 6; Output Current: 40mA; Output Voltage: -5.5V; Package Quantity: 1000; Packaging: Tape and Reel; Pricing 1: 1=0.89000, 10=0.79300, 100=0.61830, 500=0.51078 (USD); Radiation Hardening: No; REACH SVHC: No SVHC; RoHS: Yes; Stock 1: 24349; Supplier 1: Digi-Key; Supplier Part Number 1: LM2664M6/NOPBCT-ND; Switching Frequency: 160kHz; Termination: SMD/SMT; Width: 1.6mm; Library Name: EE_smallOB_BW_v1.0.SchLib
U4 = TLV70025QDDCRQ1: LDO Regulator Pos 2.5V 0.2A 5-Pin SOT T/R; Manufacturer 1: Texas Instruments; Accuracy: 2%; Dropout Voltage: 175mV; Max Input Voltage: 5.5V; Max Output Current: 200mA; Max Power Dissipation: 500mW; Min Current Limit: 220mA; Min Input Voltage: 2V; Number of Outputs: 1; Output Type: Fixed; Polarity: Positive; Quiescent Current: 30uA; Case/Package: SOT-23-5; Component Kind: Standard; ComponentLink1Description: https://datasheet.ciiva.com/29568/tlv70025-q1-29568375.pdf?src-supplier=Digi-Key; ComponentLink1URL: https://datasheet.ciiva.com/29568/tlv70025-q1-29568375.pdf?src-supplier=Digi-Key; ComponentLink2Description: https://datasheet.ciiva.com/12958/slvsa61c-120807-12958385.pdf?src-supplier=Mouser; ComponentLink2URL: https://datasheet.ciiva.com/12958/slvsa61c-120807-12958385.pdf?src-supplier=Mouser; Lead Free: Lead Free; Manufacturer Part Number 1: TLV70025QDDCRQ1; Max Operating Temperature: 125°C; Max Output Voltage: 2.5V; Min Operating Temperature: -40°C; Min Output Voltage: 2.5V; Number of Pins: 5; Number of Regulators: 1; Output Current: 200mA; Output Voltage: 2.5V; Output Voltage Accuracy: 2%; Package Quantity: 1; Packaging: Tape and Reel; Power Dissipation: 500mW; Pricing 1: 1=0.65000, 10=0.55200, 100=0.41240, 500=0.32404, 1000=0.25039 (USD); Radiation Hardening: No; RoHS: Yes; Stock 1: 2422; Supplier 1: Digi-Key; Supplier Part Number 1: 296-36764-1-ND; Library Name: EE_smallOB_BW_v1.0.SchLib
U8 = AP2112K-3.3TRG1: IC REG LINEAR 3.3V 600MA SOT25; Manufacturer 1: Diodes; Accuracy: 1.5%; Dropout Voltage: 250mV; Max Input Voltage: 6V; Min Input Voltage: 2.5V; Number of Outputs: 1; Output Type: Fixed; Polarity: Positive; Quiescent Current: 55uA; Case/Package: SOT-23-5; Component Kind: Standard; ComponentLink1Description: https://datasheet.ciiva.com/30016/ap2112-30016792.pdf?src-supplier=Avnet; ComponentLink1URL: https://datasheet.ciiva.com/30016/ap2112-30016792.pdf?src-supplier=Avnet; ComponentLink2Description: https://datasheet.ciiva.com/29569/ap2112-29569917.pdf?src-supplier=Digi-Key; ComponentLink2URL: https://datasheet.ciiva.com/29569/ap2112-29569917.pdf?src-supplier=Digi-Key; ComponentLink3Description: https://datasheet.ciiva.com/29569/ap2112-29569918.pdf?src-supplier=Digi-Key; ComponentLink3URL: https://datasheet.ciiva.com/29569/ap2112-29569918.pdf?src-supplier=Digi-Key; ComponentLink4Description: https://datasheet.ciiva.com/29569/ap2112-29569919.pdf?src-supplier=Digi-Key; ComponentLink4URL: https://datasheet.ciiva.com/29569/ap2112-29569919.pdf?src-supplier=Digi-Key; Height: 1.3mm; Lead Free: Lead Free; Length: 3.1mm; Manufacturer Part Number 1: AP2112K-3.3TRG1; Max Operating Temperature: 85°C; Max Output Current: 600mA; Min Operating Temperature: -40°C; Mount: Surface Mount; Number of Pins: 5; Number of Regulators: 1; Output Current: 600mA; Output Voltage: 3.3V; Package Quantity: 1; Packaging: Tape and Reel; Pricing 1: 1=0.47000, 10=0.35100, 100=0.21890, 500=0.14976, 1000=0.11520 (USD); RoHS: Yes; Stock 1: 101506; Supplier 1: Digi-Key; Supplier Part Number 1: AP2112K-3.3TRG1DICT-ND; Width: 1.7mm; Library Name: EE_smallOB_BW_v1.0.SchLib
VR1 = TPS72325DBVR: 200 mA Low-Noise, High-PSRR Negative Output Low-Dropout Linear Regulators; Manufacturer 1: Texas Instruments; Accuracy: 2%; Dropout Voltage: 280mV; Input Bias Current: 130uA; Max Input Voltage: -2.7V; Max Output Current: 200mA; Max Output Voltage: -2.5V; Max Power Dissipation: 560mW; Min Current Limit: 300mA; Min Input Voltage: -10V; Number of Outputs: 1; Output Type: Fixed; Output Voltage Accuracy: 1%; Case/Package: SOT-23; Component Kind: Standard; ComponentLink1Description: http://www.mouser.com/ds/2/405/slvs346c-521947.pdf?src-supplier=Mouser; ComponentLink1URL: http://www.mouser.com/ds/2/405/slvs346c-521947.pdf?src-supplier=Mouser; ComponentLink2Description: http://components.arrow.com/part/dataview/0323_00141856?src-supplier=Arrow+Europe; ComponentLink2URL: http://components.arrow.com/part/dataview/0323_00141856?src-supplier=Arrow+Europe; ComponentLink3Description: http://www.ti.com/general/docs/lit/getliterature.tsp?genericPartNumber=TPS723&amp;&amp;fileType=pdf&src-supplier=Farnell; ComponentLink3URL: http://www.ti.com/general/docs/lit/getliterature.tsp?genericPartNumber=TPS723&amp;&amp;fileType=pdf&src-supplier=Farnell; ComponentLink4Description: http://www.ti.com/general/docs/lit/getliterature.tsp?genericPartNumber=TPS723&&fileType=pdf&src-supplier=Element14; ComponentLink4URL: http://www.ti.com/general/docs/lit/getliterature.tsp?genericPartNumber=TPS723&&fileType=pdf&src-supplier=Element14; ComponentLink5Description: http://www.ti.com/general/docs/lit/getliterature.tsp?genericPartNumber=TPS723&&fileType=pdf&src-supplier=Avnet+Asia; ComponentLink5URL: http://www.ti.com/general/docs/lit/getliterature.tsp?genericPartNumber=TPS723&&fileType=pdf&src-supplier=Avnet+Asia; ComponentLink6Description: http://www.ti.com/general/docs/lit/getliterature.tsp?genericPartNumber=TPS723&&fileType=pdf&src-supplier=Avnet; ComponentLink6URL: http://www.ti.com/general/docs/lit/getliterature.tsp?genericPartNumber=TPS723&&fileType=pdf&src-supplier=Avnet; ComponentLink7Description: http://www.ti.com/lit/ds/symlink/tps723.pdf?src-supplier=Digi-Key; ComponentLink7URL: http://www.ti.com/lit/ds/symlink/tps723.pdf?src-supplier=Digi-Key; ComponentLink8Description: http://www.ti.com/general/docs/lit/getliterature.tsp?genericPartNumber=TPS723&amp;&amp;fileType=pdf&src-supplier=Newark; ComponentLink8URL: http://www.ti.com/general/docs/lit/getliterature.tsp?genericPartNumber=TPS723&amp;&amp;fileType=pdf&src-supplier=Newark; ComponentLink9Description: http://focus.ti.com/lit/ds/symlink/tps72325.pdf?src-supplier=Avnet+Europe; ComponentLink9URL: http://focus.ti.com/lit/ds/symlink/tps72325.pdf?src-supplier=Avnet+Europe; ComponentLink10Description: http://components.arrow.com/part/dataview/40177093S9611537N7713?src-supplier=Arrow; ComponentLink10URL: http://components.arrow.com/part/dataview/40177093S9611537N7713?src-supplier=Arrow; ComponentLink11Description: http://www.ti.com/lit/ds/symlink/tps72301.pdf?src-supplier=Digi-Key; ComponentLink11URL: http://www.ti.com/lit/ds/symlink/tps72301.pdf?src-supplier=Digi-Key; Contact Plating: Tin,Matte; Lead Free: Lead Free; Manufacturer Part Number 1: TPS72325DBVR; Max Operating Temperature: 125°C; Min Operating Temperature: -40°C; Min Output Voltage: -2.5V; Nominal Output Voltage: -2.5V; Number of Pins: 5; Number of Regulators: 1; Output Current: 200mA; Output Voltage: -2.5V; Package Quantity: 3000; Packaging: Tape and Reel; Polarity: Negative; Power Dissipation: 560mW; Pricing 1: 1=2.81000, 10=2.52200, 100=2.02720, 500=1.66552, 1000=1.37999 (USD); Quiescent Current: 130uA; Radiation Hardening: No; REACH SVHC: No SVHC; RoHS: Yes; Stock 1: 4645; Supplier 1: Digi-Key; Supplier Part Number 1: 296-39479-1-ND; Library Name: EE_smallOB_BW_v1.0.SchLib
